# Altium schematic sheet MAIN.SchDoc
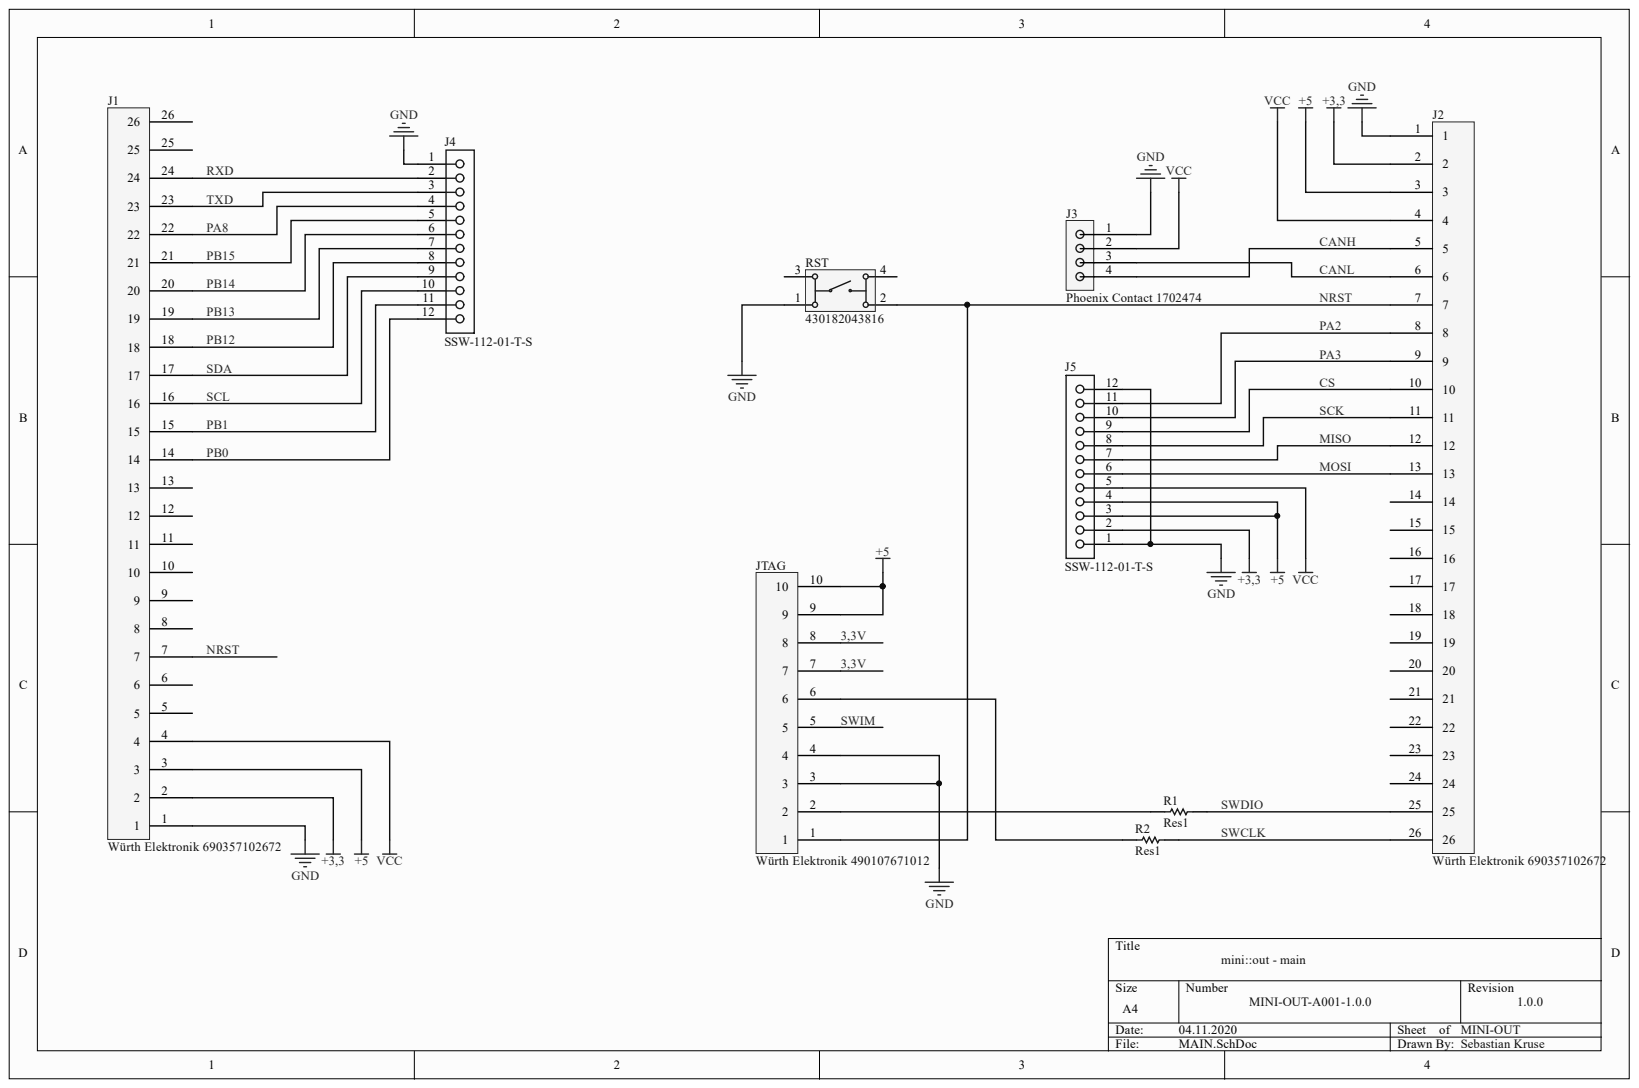
[diagram p0: MAIN.SchDoc]

## Parts
J1  Würth Elektronik 690357102672  Manufacturer Part Number: 690357102672  [PCB-qkngfwumds4pa5a4mwtz-1]
J2  Würth Elektronik 690357102672  [PCB-qkngfwumds4pa5a4mwtz-1]
J3  Phoenix Contact 1702474  Manufacturer Part Number: 1702474  [PCB-0s34z00om61ronl3soi2-1]
J4  SSW-112-01-T-S  [SMTC-SSW-112-01-X-S]
J5  SSW-112-01-T-S  [SMTC-SSW-112-01-X-S]
JTAG  Würth Elektronik 490107671012  [PCB-zeumetx1dr0218b14v4a-1]
R1  Res1  [PCB-xa88fof6me2djvx7pfp2-1]
R2  Res1  [PCB-xa88fof6me2djvx7pfp2-1]
RST  430182043816  [430182043816]

## Nets
+3,3: J1.2 J2.2 J5.2
+5: J1.3 J2.3 J5.3 J5.4 JTAG.9 JTAG.10
GND: J1.1 J2.1 J3.1 J4.1 J5.1 J5.12 JTAG.3 JTAG.4 RST.1
VCC: J1.4 J2.4 J3.2 J5.5
3,3V: JTAG.7 JTAG.8
CANH: J2.5 J3.4
CANL: J2.6 J3.3
CS: J2.10 J5.9
MISO: J2.12 J5.7
MOSI: J2.13 J5.6
NRST: J1.7 J2.7 JTAG.1 RST.2
PA2: J2.8 J5.11
PA3: J2.9 J5.10
PA8: J1.22 J4.4
PB0: J1.14 J4.12
PB1: J1.15 J4.11
PB12: J1.18 J4.8
PB13: J1.19 J4.7
PB14: J1.20 J4.6
PB15: J1.21 J4.5
RXD: J1.24 J4.2
SCK: J2.11 J5.8
SCL: J1.16 J4.10
SDA: J1.17 J4.9
SWCLK: J2.26 R2.2
SWDIO: J2.25 R1.2
SWIM: JTAG.5
TXD: J1.23 J4.3
NetJTAG_2: JTAG.2 R1.1
NetJTAG_6: JTAG.6 R2.1

Not connected: J1 5 6 8 9 10 11 12 13 25 26; J2 14 15 16 17 18 19 20 21 22 23 24; RST 3 4

## Library data of the parts
J1 J2 = CMP-cccf062e80c51308-1: Male THT Straight Connector without Latch and with Polarization WR-MM, 26 pins; Contact Resistance: 10.0 m?; Current Rating: 1.50 A; Dielectric Withstanding Voltage: 500 V; Insulation Resistance: 1.00 ? (min); Operating Voltage: 100 V; Pin Pitch: 2.54 mm; Component Kind: Standard; Contact Material: Phosphor Bronze; Gender: Male; Library Name: FavoritesLibrary; Mounting Style: Through Hole; Number of Pins: 26; OctopartID: cccf062e80c51308; Octopart URL: https://octopart.com/690357102672-w%C3%BCrth+elektronik-65759596; Operating Temperature: -40.0 °C (min); Packaging: Tube
  J2: Manufacturer Part Number: 690357102672
J3 = CMP-aa9f62a41ea57558-1: Fixed Miniature Connectors, Spring Cage, Horizontal, SMD, 4 pos; Lead-Free Status: Lead Free; Color: Black; Component Kind: Standard; Contact Plating: Tin; Flammability Rating: UL 94 V-0; Housing Color: Black; Library Name: CommunityVault; Mounting Style: Surface Mount; OctopartID: aa9f62a41ea57558; Packaging: Reel; RoHS: Compliant
J4 J5 = CMP-678722-2: SQ Post Socket, Through-hole, Vertical, -55 to 105 degC, 2.54 mm Pitch, 12-Pin, Female, RoHS; Lead-Free Status: Lead Free; Number of Contacts: 12; Number of Mating Cycles: 100; Pin Pitch: 0.00254 m; Color: Black; Component Kind: Standard; Contact Material: Phosphor Bronze; Contact Plating: Tin; Gender: Female; Housing Color: Black; Housing Material: Plastic; Library Name: CommunityVault; Manufacturer Part Number: SSW-112-01-T-S; Mounting Style: Through Hole; Number of Positions: 12; Number of Rows: 1; OctopartID: 127ddb73b250cdba; Octopart Url: https://octopart.com/ssw-112-01-t-s-samtec-296267; Packaging: Tube; REACH SVHC Compliance: No SVHC; RoHS: Yes; Size-Height: 0.00851 m; Size-Length: 0.03099 m; Size-Width: 0.00241 m
JTAG = CMP-05c1c9f7b3abda06-1: REDFIT IDC SKEDD Connector WR-WST, pitch 2.54 mm, 10 pins; Contact Resistance: 10.0 mΩ; Gender: Female; Pin Pitch: 2.54 mm; Component Kind: Standard; Contact Material: Copper Alloy; Contact Plating: Gold; Library Name: CommunityVault; Number of Pins: 10; Octopart URL: https://octopart.com/490107671012-w%C3%BCrth+elektronik-87855884; Operating Temperature: 105 °C (max); Packaging: Tube; RoHS: Compliant
R1 R2 = CMP-653aac19586dbafd-1: Res Thick Film 0603 22 Ohm 5% 1/10W ±200ppm/°C Molded SMD SMD Paper Tape on Plastic Reel; Component Kind: Standard; Library Name: CommunityVault; RoHS: Compliant
RST = CMP-1187753-2: WS-TASV SMD Tact Switch 6X6 mm; Contact Resistance: 0.1 Ω; Contacts Type: DPST; Insulation Resistance: 100000000.0 Ω; Component Kind: Standard; Library Name: CommunityVault; Manufacturer Part Number: 430182043816; OctopartID: 6129a97f9392beee; Octopart Url: https://octopart.com/430182043816-w%C3%BCrth+elektronik-22861789; REACH SVHC Compliance: No SVHC; RoHS: Compliant; Size-Height: 0.0043 m
